# Altium schematic sheet Page5_GPS.SchDoc(Page5_GPS)
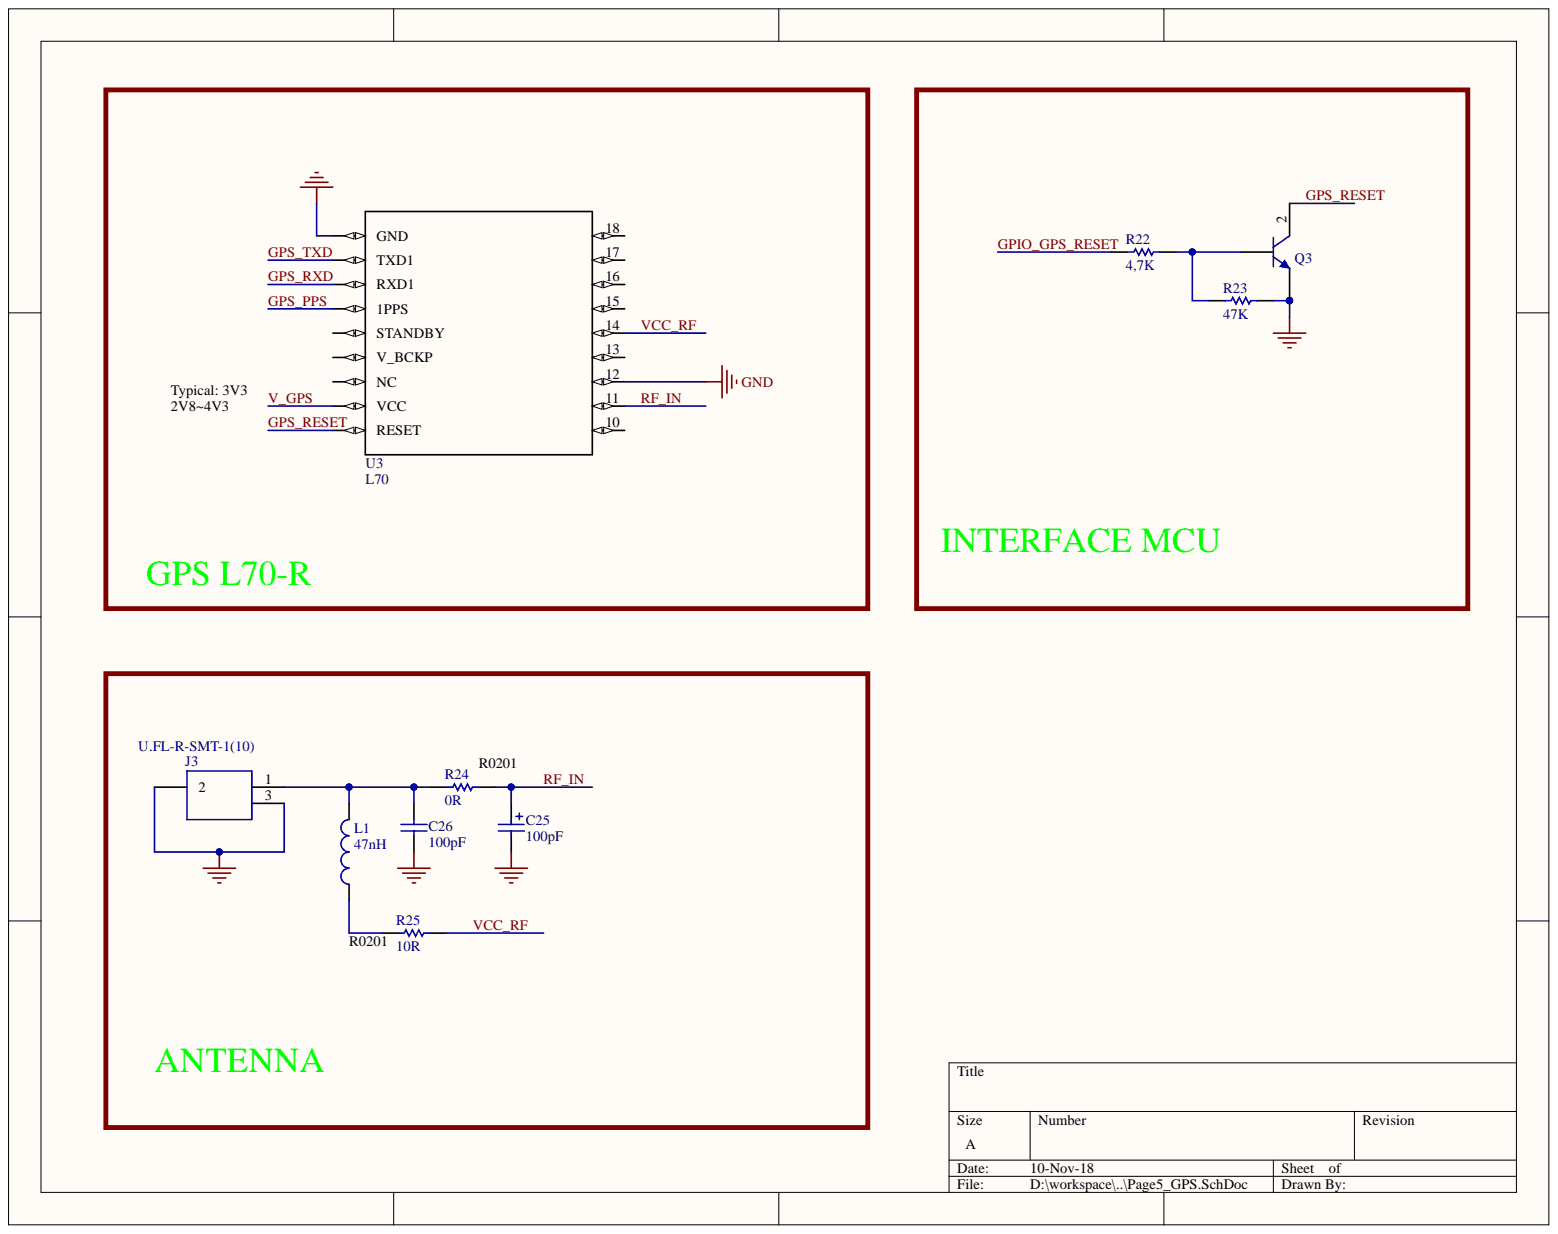
[diagram p0: Page5_GPS.SchDoc]

## Parts
C25  value 100pF  [CAP ALU SIZE B]  (hidden comment: Cap Pol)
C26  value 100pF  [CAP-SM-0603]  (hidden comment: Cap Non-Pol SMD)
J3  U.FL-R-SMT-1(10)  [U.FL-R-SMT-1(10)]
L1  value 47nH  [INDUCTOR 12.5x12.5]  (hidden comment: Inductor)
Q3  C1815  [SOT23B]  (value not drawn)
R22  value 4,7K  [RES-SM-0603]  (hidden comment: RESISTOR SMD)
R23  value 47K  [RES-SM-0603]  (hidden comment: RESISTOR SMD)
R24  value 0R  [RES-SM-0603]  (hidden comment: RESISTOR SMD)
R25  value 10R  [RES-SM-0603]  (hidden comment: RESISTOR)
U3  L70  [MOD18]

## Nets
GND: C25.2 C26.1 J3.2 J3.3 Q3.1 R23.2 U3.1(GND) U3.12
GPIO_GPS_RESET: R22.1
GPS_PPS: U3.4(1PPS)
GPS_RESET: Q3.2 U3.9(RESET)
GPS_RXD: U3.3(RXD1)
GPS_TXD: U3.2(TXD1)
RF_IN: C25.1 R24.2 U3.11
V_GPS: U3.8(VCC)
VCC_RF: R25.2 U3.14
NetC26_2: C26.2 J3.1 L1.2 R24.1
NetL1_1: L1.1 R25.1
NetQ3_3: Q3.3 R22.2 R23.1

Not connected: U3 5(STANDBY) 6(V_BCKP) 7(NC) 10 13 15 16 17 18

## Library data of the parts
C25 = Cap Pol: Polarized Capacitor (Radial); Library Name: PIF_Library.IntLib; Component Kind: Standard
C26 = Cap Non-Pol SMD: Capacitor; Library Name: PIF_Library.IntLib; Component Kind: Standard
J3 = U.FL-R-SMT-1(10): UFL SMT PCB receptable,DC-6GHz Hirose Straight 50 Surface Mount UFL Connector, Receptacle, Solder Termination Coaxial; RS Price/Stock: http://uk.rs-online.com/web/p/products/1757510; RS Part Number: 1757510; Manufacturer_Part_Number: U.FL-R-SMT-1(10); Manufacturer_Name: Hirose; Library Name: U.FL-R-SMT-1(10).SchLib; Datasheet Link: https://www.hirose.com/product/en/download_file/key_name/U.FL-R-SMT-1%2810%29/category/Drawing%20(2D)/doc_file_id/37627/?file_category_id=6&item_id=03310472210&is_series=; Component Kind: Standard; Arrow Price/Stock: https://www.arrow.com/en/products/u.fl-r-smt-1-10/hirose-electric; Arrow Part Number: U.FL-R-SMT-1(10)
Q3 = C1815: NPN Bipolar Transistor; Library Name: PIF_Library.IntLib; Component Kind: Standard
R22-R24 = RESISTOR SMD: Resistor; Library Name: PIF_Library.IntLib; Component Kind: Standard
U3 = L70: Gps Module + Mt3339 + 9600bps; MF: Quectel; SnapEDA_Link: https://www.snapeda.com/parts/L70/Quectel/view-part/?ref=snap; Price: None; Package: None; MP: L70; Library Name: L70.SchLib; Component Kind: Standard; Availability: Unavailable
L1 = Inductor: Library Name: PIF_Library.IntLib; Description: Inductor; Component Kind: Standard
R25 = RESISTOR: Library Name: PIF_Library.IntLib; Description: Resistor; Component Kind: Standard
